# Altium schematic sheet Pag2_Battery_Charger.SchDoc(Pag2_Battery_Charger)
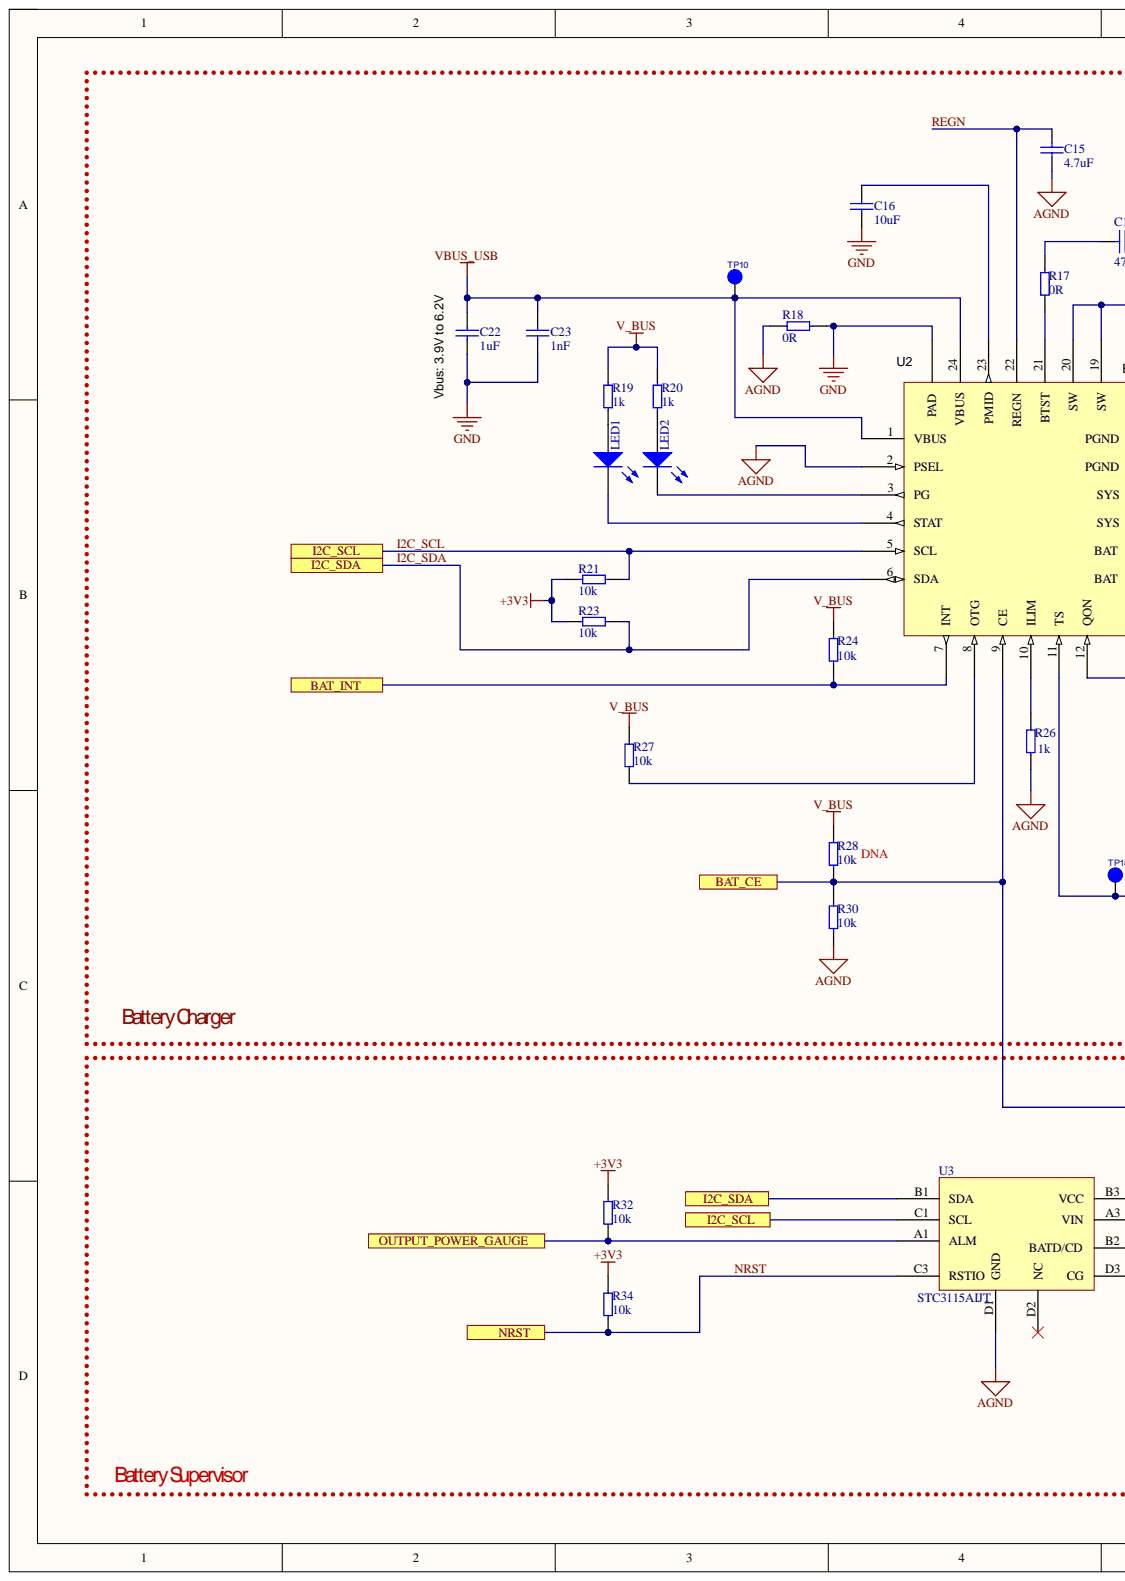
[diagram p0: Pag2_Battery_Charger.SchDoc, left]
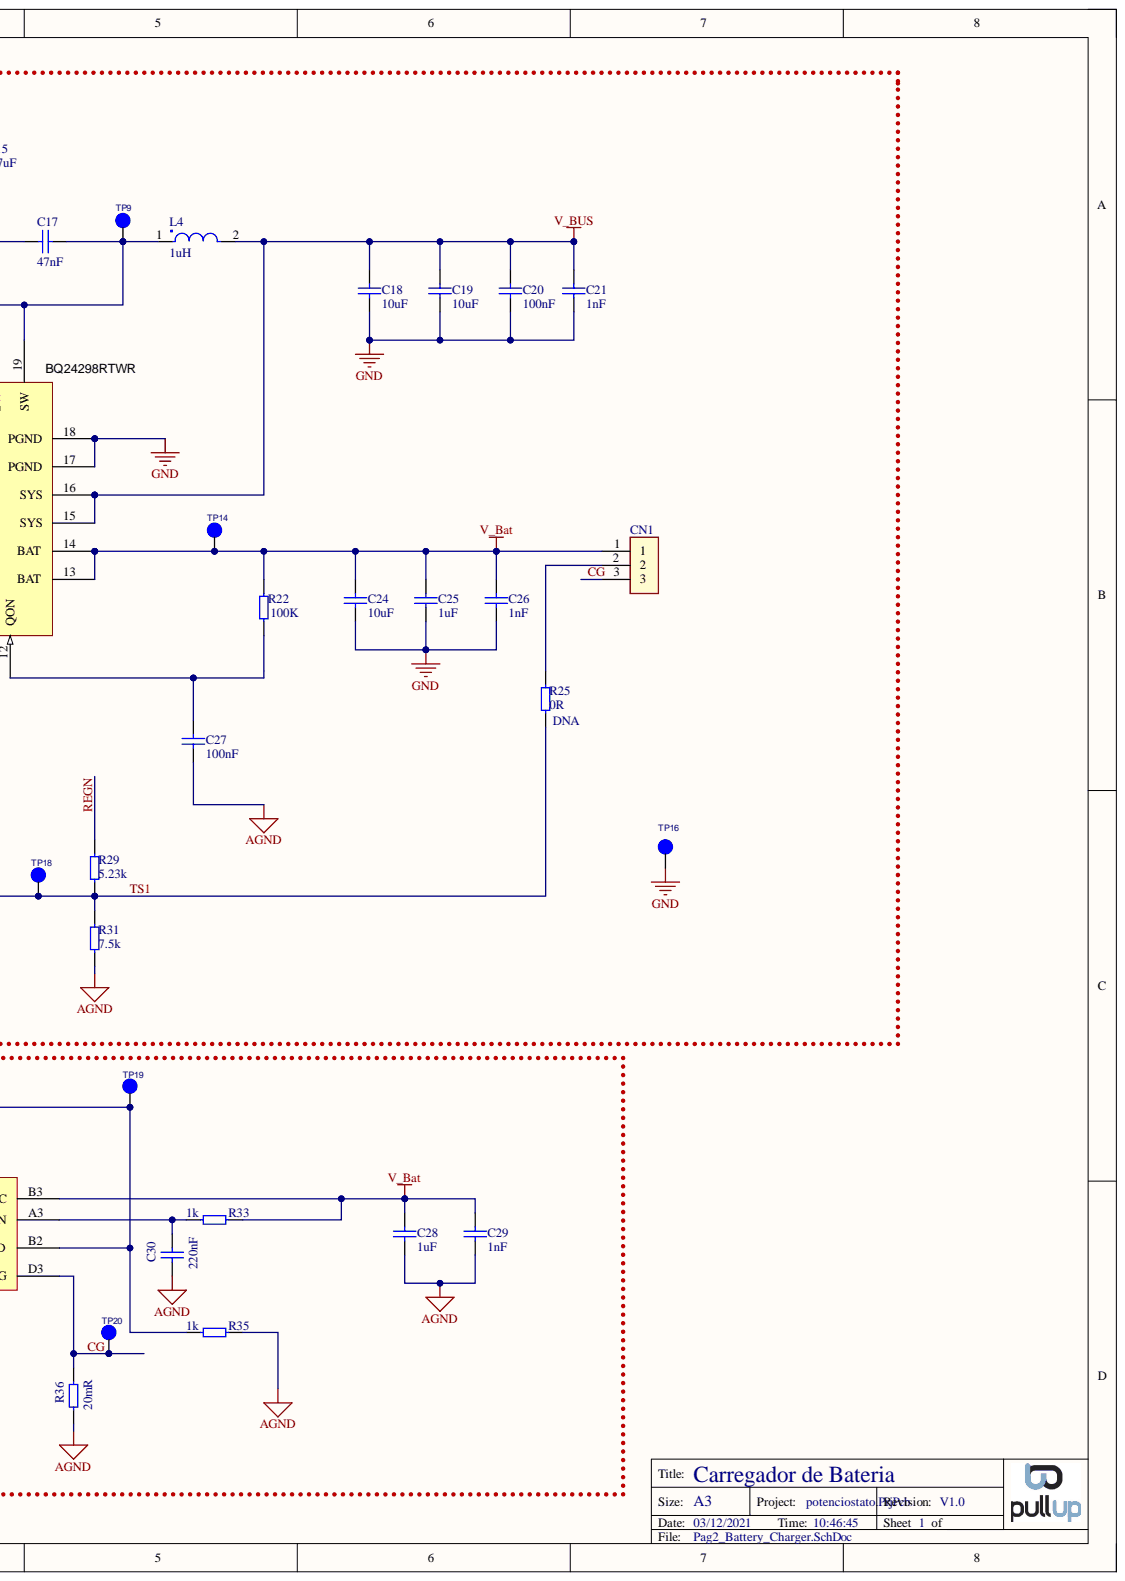
[diagram p1: Pag2_Battery_Charger.SchDoc, right]

## Parts
C15  4.7uF  [0603]
C16  10uF  [0603]
C17  47nF  [0603]
C18  10uF  [0603]
C19  10uF  [0603]
C20  100nF  [0603]
C21  1nF  [0603]
C22  1uF  [0603]
C23  1nF  [0603]
C24  10uF  [0603]
C25  1uF  [0603]
C26  1nF  [0603]
C27  100nF  [0603]
C28  1uF  [0603]
C29  1nF  [0603]
C30  220nF  [0603]
CN1  (no value)  [HDR1X3 Large]
L4  1uH  [SRP4012TA]
LED1  LED Green  [0603 - led]  (value not drawn)
LED2  LED Green  [0603 - led]  (value not drawn)
R17  0R  [0603]
R18  0R  [1218]
R19  1k  [0603]
R20  1k  [0603]
R21  10k  [0603]
R22  100K  [0603]
R23  10k  [0603]
R24  10k  [0603]
R25  0R  PCBA: DNA  [0603]
R26  1k  [0603]
R27  10k  [0603]
R28  10k  PCBA: DNA  [0603]
R29  5.23k  [0603]
R30  10k  [0603]
R31  7.5k  [0603]
R32  10k  [0603]
R33  1k  [0603]
R34  10k  [0603]
R35  1k  [0603]
R36  20mR  [0805]
TP9  Test_Point  [TEST]  (value not drawn)
TP10  Test_Point  [TEST]  (value not drawn)
TP14  Test_Point  [TEST]  (value not drawn)
TP16  Test_Point  [TEST]  (value not drawn)
TP18  Test_Point  [TEST]  (value not drawn)
TP19  Test_Point  [TEST]  (value not drawn)
TP20  Test_Point  [TEST]  (value not drawn)
U2  BQ24298RTWR  [WQFN-24]
U3  STC3115AIJT  [CSP1.4X2.04]

## Ports
BAT_CE, BAT_INT, I2C_SCL, I2C_SDA, NRST, OUTPUT_POWER_GAUGE

## Nets
+3V3: R21.2 R23.2 R32.1 R34.1
AGND: C15.1 C27.1 C28.1 C29.1 C30.1 R18.1 R26.1 R30.2 R31.1 R35.1 R36.2 U2.2(PSEL) U3.D1(GND)
GND: C16.1 C18.1 C19.1 C20.1 C21.1 C22.1 C23.1 C24.1 C25.1 C26.1 R18.2 TP16.1 U2.17(PGND) U2.18(PGND) U2.25(PAD)
V_Bat: C24.2 C25.2 C26.2 C28.2 C29.2 CN1.1 R22.1 R33.1 TP14.1 U2.13(BAT) U2.14(BAT) U3.B3(VCC)
V_BUS: C18.2 C19.2 C20.2 C21.2 L4.2 R19.2 R20.2 R24.1 R27.1 R28.1 U2.15(SYS) U2.16(SYS)
VBUS_USB: C22.2 C23.2 TP10.1 U2.1(VBUS) U2.24(VBUS)
BAT_CE: R28.2 R30.1 R35.2 TP19.1 U2.9(CE) U3.B2(BATD/CD) ; port BAT_CE
BAT_INT: R24.2 U2.7(INT) ; port BAT_INT
CG: CN1.3 R36.1 TP20.1 U3.D3(CG)
I2C_SCL: R21.1 U2.5(SCL) U3.C1(SCL) ; port I2C_SCL
I2C_SDA: R23.1 U2.6(SDA) U3.B1(SDA) ; port I2C_SDA
NRST: R34.2 U3.C3(RSTIO) ; port NRST
OUTPUT_POWER_GAUGE: R32.2 U3.A1(ALM) ; port OUTPUT_POWER_GAUGE
REGN: C15.2 R29.2 U2.22(REGN)
TS1: R25.2 R29.1 R31.2 TP18.1 U2.11(TS)
NetC16_2: C16.2 U2.23(PMID)
NetC17_1: C17.1 L4.1 TP9.1 U2.19(SW) U2.20(SW)
NetC17_2: C17.2 R17.2
NetC27_2: C27.2 R22.2 U2.12(QON)
NetC30_2: C30.2 R33.2 U3.A3(VIN)
NetCN1_2: CN1.2 R25.1
NetLED1_1: LED1.1 R19.1
NetLED1_2: LED1.2 U2.4(STAT)
NetLED2_1: LED2.1 R20.1
NetLED2_2: LED2.2 U2.3(PG)
NetR17_1: R17.1 U2.21(BTST)
NetR26_2: R26.2 U2.10(ILIM)
NetR27_2: R27.2 U2.8(OTG)

Not connected: U3 D2(NC)

## Library data of the parts
C15 = CAP_4.7uF_16V: Manufacturer: Taiyo Yuden; MPN: JMK107BJ475KA-T; Additional Info: 6.3V; Database Table Name: Capacitor$; PCBA: Assembly; Component Kind: Standard; Datasheet: https://www.mouser.com/datasheet/2/396/mlcc02_e-1307760.pdf; Supplier 1: Mouser; Supplier 1 Link: https://www.mouser.com/ProductDetail/Taiyo-Yuden/JMK107BJ475KA-T?qs=I6KAKw0tg2xvhAp2%2FY8pSQ%3D%3D; Supplier 1 Part Number: 963-JMK107BJ475KA-T; Value: 4.7uF; Library Name: Database_Pullup.DbLib
C16 C18 C19 C24 = CAP_10uF_10V: Manufacturer: Murata; MPN: GRM188R61A106KE69J; Database Table Name: Capacitor$; PCBA: Assembly; Component Kind: Standard; Datasheet: http://www.mouser.com/ds/2/281/c02e-2905.pdf; Supplier 1: Mouser; Supplier 1 Link: http://www.mouser.com/ProductDetail/Murata-Electronics/GRM188R61A106KE69J/?qs=sGAEpiMZZMs0AnBnWHyRQAsAWwhBCY7bp3pFrpMtukFBX6VOqeHlKw%3d%3d; Supplier 1 Part Number: 81-GRM188R61A106KE9J; Value: 10uF; Library Name: Database_Pullup.DbLib
C17 = CAP_4.7uF_16V: Manufacturer: AVX; MPN: 0603YC473KAT2A; Database Table Name: Capacitor$; PCBA: Assembly; Component Kind: Standard; Datasheet: http://www.mouser.com/ds/2/40/X7RDielectric-777024.pdf; Supplier 1: Mouser; Supplier 1 Link: http://br.mouser.com/ProductDetail/AVX/0603YC473KAT2A/?qs=sGAEpiMZZMs0AnBnWHyRQAeeFNb%252bZ377aQFEP6Zo9NY%3d; Supplier 1 Part Number: 581-0603YC473K; Value: 47nF; Library Name: Database_Pullup.DbLib
C20 C27 = CAP_100nF_10V: Manufacturer: KEMET; MPN: C0603C104M4RACAUTO; Database Table Name: Capacitor$; PCBA: Assembly; Component Kind: Standard; Datasheet: https://www.mouser.com/datasheet/2/212/KEM_C1023_X7R_AUTO_SMD-1093309.pdf; Supplier 1: Mouser; Supplier 1 Link: https://www.mouser.com/ProductDetail/KEMET/C0603C104M4RACAUTO?qs=sSYV1F9c5cFMyVgVMlPozw%3D%3D; Supplier 1 Part Number: 80-C0603C104M4RAUTO; Value: 100nF; Library Name: Database_Pullup.DbLib
C21 C26 = CAP_1nF_16V: Manufacturer: Yageo; MPN: CC0603JRX7R8BB102; Database Table Name: Capacitor$; PCBA: Assembly; Component Kind: Standard; Datasheet: https://www.mouser.com/datasheet/2/447/UPY-GPHC_X7R_6.3V-to-50V_18-1154002.pdf; Supplier 1: Mouser; Supplier 1 Link: https://www.mouser.com/ProductDetail/Yageo/CC0603JRX7R8BB102?qs=57cj7OiSijlaKxXXAw1Kzw%3D%3D; Supplier 1 Part Number: 603-CC603JRX7R8BB102; Value: 1nF; Library Name: Database_Pullup.DbLib
C22 C28 = CAP_1uF: Manufacturer: Samsung Electro-Mechanics; MPN: CL10A105KA8NNNC; Database Table Name: Capacitor$; PCBA: Assembly; Component Kind: Standard; Datasheet: https://www.mouser.com/datasheet/2/585/MLCC-1837944.pdf; Supplier 1: Mouser; Supplier 1 Link: https://www.mouser.com/ProductDetail/Samsung-Electro-Mechanics/CL10A105KA8NNNC?qs=hqM3L16%252BxldY%252BnK4KzDJVg%3D%3D; Supplier 1 Part Number: 187-CL10A105KA8NNNC; Value: 1uF; Library Name: Database_Pullup.DbLib
C23 C29 = CAP_1nF: Manufacturer: Yageo; MPN: CC0603JRX7R8BB102; Database Table Name: Capacitor$; PCBA: Assembly; Component Kind: Standard; Datasheet: https://www.mouser.com/datasheet/2/447/UPY-GPHC_X7R_6.3V-to-50V_18-1154002.pdf; Supplier 1: Mouser; Supplier 1 Link: https://www.mouser.com/ProductDetail/Yageo/CC0603JRX7R8BB102?qs=57cj7OiSijlaKxXXAw1Kzw%3D%3D; Supplier 1 Part Number: 603-CC603JRX7R8BB102; Value: 1nF; Library Name: Database_Pullup.DbLib
C25 = CAP_1uF_16V: Manufacturer: Samsung Electro-Mechanics; MPN: CL10A105KA8NNNC; Database Table Name: Capacitor$; PCBA: Assembly; Component Kind: Standard; Datasheet: https://www.mouser.com/datasheet/2/585/MLCC-1837944.pdf; Supplier 1: Mouser; Supplier 1 Link: https://www.mouser.com/ProductDetail/Samsung-Electro-Mechanics/CL10A105KA8NNNC?qs=hqM3L16%252BxldY%252BnK4KzDJVg%3D%3D; Supplier 1 Part Number: 187-CL10A105KA8NNNC; Value: 1uF; Library Name: Database_Pullup.DbLib
C30 = CAP_1nF: Manufacturer: Yageo; MPN: CC0603KRX7R7BB224; Database Table Name: Capacitor$; PCBA: Assembly; Component Kind: Standard; Datasheet: https://www.mouser.com/datasheet/2/447/UPY-GPHC_X7R_6.3V-to-50V_18-1154002.pdf; Supplier 1: Mouser; Supplier 1 Link: https://www.mouser.com/ProductDetail/Yageo/CC0603KRX7R7BB224?qs=sGAEpiMZZMvsSlwiRhF8qj9NgEuXmwzvLL7kcSnC7TY%3D; Supplier 1 Part Number: 603-CC603KRX7R7BB224; Value: 220nF; Library Name: Database_Pullup.DbLib
CN1 = 53254-0370: MPN: Header; PCBA: DNA; Component Kind: Standard; Library Name: LIBSCH_PULLUP.SchLib
L4 = IC_1uH: Manufacturer: Bourns; MPN: SRP4012TA-1R0M; Database Table Name: Indutores$; PCBA: Assembly; Component Kind: Standard; Datasheet: https://www.mouser.com/datasheet/2/54/rp4012ta-1391620.pdf; Supplier 1: Mouser; Supplier 1 Link: https://www.mouser.com/ProductDetail/Bourns/SRP4012TA-1R0M?qs=%2Fha2pyFaduhnZC9XlWRPjS9IbC2g%252Bs6L7AFI%2FwucAauXoSPPWYzFLg%3D%3D; Supplier 1 Part Number: 652-SRP4012TA-1R0M; Value: 1uH; Library Name: Database_Pullup.DbLib
LED1 LED2 = CDE02104-C024: Manufacturer: Kingbright; MPN: APG1608CGKC/T; Database Table Name: Led$; PCBA: Assembly; Component Kind: Standard; Datasheet: http://www.mouser.com/ds/2/216/APG1608CGKC-T-76846.pdf; Supplier 1: Mouser; Supplier 1 Link: http://www.mouser.com/ProductDetail/Kingbright/APG1608CGKC-T/?qs=sGAEpiMZZMseGfSY3csMkQG%252bg73CMKmdo%2fwhIUL1hIE%3d; Supplier 1 Part Number: 604-APG1608CGKC/T; Value: LED Green; Library Name: Database_Pullup.DbLib
R17 = Pullup_Res_0_0603_RES: Manufacturer: Yageo; MPN: RC0603JR-070RL; Database Table Name: Resistores$; PCBA: Assembly; Component Kind: Standard; Datasheet: https://www.mouser.com/datasheet/2/447/PYu-RC_Group_51_RoHS_L_10-1527934.pdf; Library Name: Database_Pullup.DbLib; Supplier 1: Mouser; Supplier 1 Link: https://www.mouser.com/ProductDetail/Yageo/RC0603JR-070RL?qs=sGAEpiMZZMu61qfTUdNhG5eFuApKbqVdMPNPP1VDJp4%3d; Supplier 1 Part Number: 603-RC0603JR-070RL; Value: 0R
R18 = RES_0R_1218: Manufacturer: Yageo; MPN: RC1218JK-070RL; Database Table Name: Resistor$; PCBA: Assembly; Component Kind: Standard; Datasheet: http://www.mouser.com/ds/2/447/PYu-RC1218_51_RoHS_L_(3)-2499.pdf; Supplier 1: Mouser; Supplier 1 Link: http://www.mouser.com/ProductDetail/Yageo/RC1218JK-070RL/?qs=sGAEpiMZZMvdGkrng054t%2fjcxOrcSHNr2Q9lXz27jXA%3d; Supplier 1 Part Number: 603-RC1218JK-070RL; Value: 0R; Library Name: Database_Pullup.DbLib
R19 R20 R26 R33 R35 = Pullup_Res_1k_0603_RES: Manufacturer: Yageo; MPN: RC0603JR-071KL; Database Table Name: Resistores$; PCBA: Assembly; Component Kind: Standard; Datasheet: https://www.mouser.com/datasheet/2/447/PYu-RC_Group_51_RoHS_L_10-1527934.pdf; Library Name: Database_Pullup_New.DbLib; Supplier 1: Mouser; Supplier 1 Link: https://www.mouser.com/ProductDetail/Yageo/RC0603JR-071KL?qs=sGAEpiMZZMu61qfTUdNhG5eFuApKbqVd0r5fNalZWmI%3d; Supplier 1 Part Number: 603-RC0603JR-071KL; Value: 1k
R21 R23 R24 R27 R30 R32 R34 = RES_10k: Manufacturer: Yageo; MPN: RC0603JR-0710KL; Database Table Name: Resistor$; PCBA: Assembly; Component Kind: Standard; Datasheet: https://www.mouser.com/datasheet/2/447/PYu-RC_Group_51_RoHS_L_10-1527934.pdf; Supplier 1: Mouser; Supplier 1 Link: https://www.mouser.com/ProductDetail/Yageo/RC0603JR-0710KL?qs=sGAEpiMZZMu61qfTUdNhG0IfGdGRhTL5km%252bfjRkhZ3w%3d; Supplier 1 Part Number: 603-RC0603JR-0710KL; Value: 10k; Library Name: Database_Pullup_New.DbLib
R22 = Pullup_Res_100K_0603_RES: Manufacturer: Yageo; MPN: RC0603JR-07100KL; Database Table Name: Resistores$; PCBA: Assembly; Component Kind: Standard; Datasheet: https://www.mouser.com/datasheet/2/447/PYu-RC_Group_51_RoHS_L_10-1527934.pdf; Library Name: Database_Pullup.DbLib; Supplier 1: Mouser; Supplier 1 Link: https://www.mouser.com/ProductDetail/Yageo/RC0603JR-07100KL?qs=sGAEpiMZZMu61qfTUdNhG5eFuApKbqVdI3BI7%252bGKRK0%3d; Supplier 1 Part Number: 603-RC0603JR-07100KL; Value: 100K
R25 = Pullup_Res_0_0603_RES: Manufacturer: Yageo; MPN: RC0603JR-070RL; Database Table Name: Resistores$; Component Kind: Standard; Datasheet: https://www.mouser.com/datasheet/2/447/PYu-RC_Group_51_RoHS_L_10-1527934.pdf; Library Name: Database_Pullup.DbLib; Supplier 1: Mouser; Supplier 1 Link: https://www.mouser.com/ProductDetail/Yageo/RC0603JR-070RL?qs=sGAEpiMZZMu61qfTUdNhG5eFuApKbqVdMPNPP1VDJp4%3d; Supplier 1 Part Number: 603-RC0603JR-070RL; Value: 0R
R28 = RES_10k: Manufacturer: Yageo; MPN: RC0603JR-0710KL; Database Table Name: Resistor$; Component Kind: Standard; Datasheet: https://www.mouser.com/datasheet/2/447/PYu-RC_Group_51_RoHS_L_10-1527934.pdf; Supplier 1: Mouser; Supplier 1 Link: https://www.mouser.com/ProductDetail/Yageo/RC0603JR-0710KL?qs=sGAEpiMZZMu61qfTUdNhG0IfGdGRhTL5km%252bfjRkhZ3w%3d; Supplier 1 Part Number: 603-RC0603JR-0710KL; Value: 10k; Library Name: Database_Pullup_New.DbLib
R29 = RES_5.23k: Manufacturer: KOA; MPN: RK73H1JTTD5231F; Database Table Name: Resistor$; PCBA: Assembly; Component Kind: Standard; Datasheet: http://www.mouser.com/ds/2/219/RK73H-5715.pdf; Supplier 1: Mouser; Supplier 1 Link: http://www.mouser.com/ProductDetail/KOA-Speer/RK73H1JTTD5231F/?qs=sGAEpiMZZMu61qfTUdNhG6ZLGYxAZFf%252bNtlABezRulU%3d; Supplier 1 Part Number: 660-RK73H1JTTD5231F; Value: 5.23k; Library Name: Database_Pullup_New.DbLib
R31 = RES_31.6k: Manufacturer: KOA Speer; MPN: RN73R1JTTD7501B25; Database Table Name: Resistor$; PCBA: Assembly; Component Kind: Standard; Datasheet: https://br.mouser.com/datasheet/2/219/RN73R-1544889.pdf; Supplier 1: Mouser; Supplier 1 Link: https://br.mouser.com/ProductDetail/KOA-Speer/RN73R1JTTD7501B25?qs=sGAEpiMZZMukHu%252BjC5l7YWGn%252By33OlZvC9a%2FZPucNW0%3D; Supplier 1 Part Number: 660-RN73R1JTD7501B25; Value: 7.5k; Library Name: Database_Pullup_New.DbLib
R36 = Pullup_Res_1k_0603_RES: Manufacturer: Vishay / Dale; MPN: PE0603FRF070R02L; Additional Info: 1%; Database Table Name: Resistores$; PCBA: Assembly; Component Kind: Standard; Datasheet: https://br.mouser.com/datasheet/2/447/yageodocoutput-1518764.pdf; Library Name: Database_Pullup_New.DbLib; Supplier 1: Mouser; Supplier 1 Link: https://br.mouser.com/ProductDetail/?qs=bPYCDBrImPdmJEVk4Uzabw%3D%3D; Supplier 1 Part Number: 603-PE0603FRF070R02L; Value: 20mR
TP9 TP10 TP14 TP16 TP18-TP20 = CDO08200-9892: Database Table Name: Outros$; PCBA: DNA; Component Kind: Standard; MPN: Test_Point; Value: Test_Point; Library Name: Database_Pullup.DbLib
U2 = IC_BQ24298RTWT: Battery Management I2C Controlled Single Cell 3A USB Charger with NVDC Power Path and Adjustable Voltage USB OTG 24-WQFN; Manufacturer: Texas Instruments; Database Table Name: CIs$; PCBA: Assembly; Component Kind: Standard; Datasheet: http://www.ti.com/general/docs/suppproductinfo.tsp?distId=26&gotoUrl=http%3A%2F%2Fwww.ti.com%2Flit%2Fgpn%2Fbq24298; MPN: BQ24298RTWR; Supplier 1: Mouser; Supplier 1 Link: https://br.mouser.com/ProductDetail/Texas-Instruments/BQ24298RTWR?qs=sGAEpiMZZMsfD%252BbMpEGFJeyfNo0S8ytOr4ULNPVXDJM%3D; Supplier 1 Part Number: 595-BQ24298RTWR; Value: BQ24298RTWR; Library Name: Database_Pullup.DbLib
U3 = STC3100: Battery Management CONDITIONING & INTERFACES; Manufacturer: STMicroelectronics; PCBA: Assembly; Component Kind: Standard; Datasheet: https://br.mouser.com/datasheet/2/389/stc3115-974248.pdf; MPN: STC3115AIJT; Supplier 1: Mouser; Supplier 1 Link: https://br.mouser.com/ProductDetail/STMicroelectronics/STC3115AIJT?qs=%2Fha2pyFaduiWshb%252BeOUt%252BmfVWBXtd5FUU42Aafl0Iby9GQMM96h3Pw%3D%3D; Supplier 1 Part Number: 511-STC3115AIJT; Value: STC3115AIJT; Library Name: LIBSCH_PULLUP.SchLib
